# Altium schematic sheet l1.SchDoc
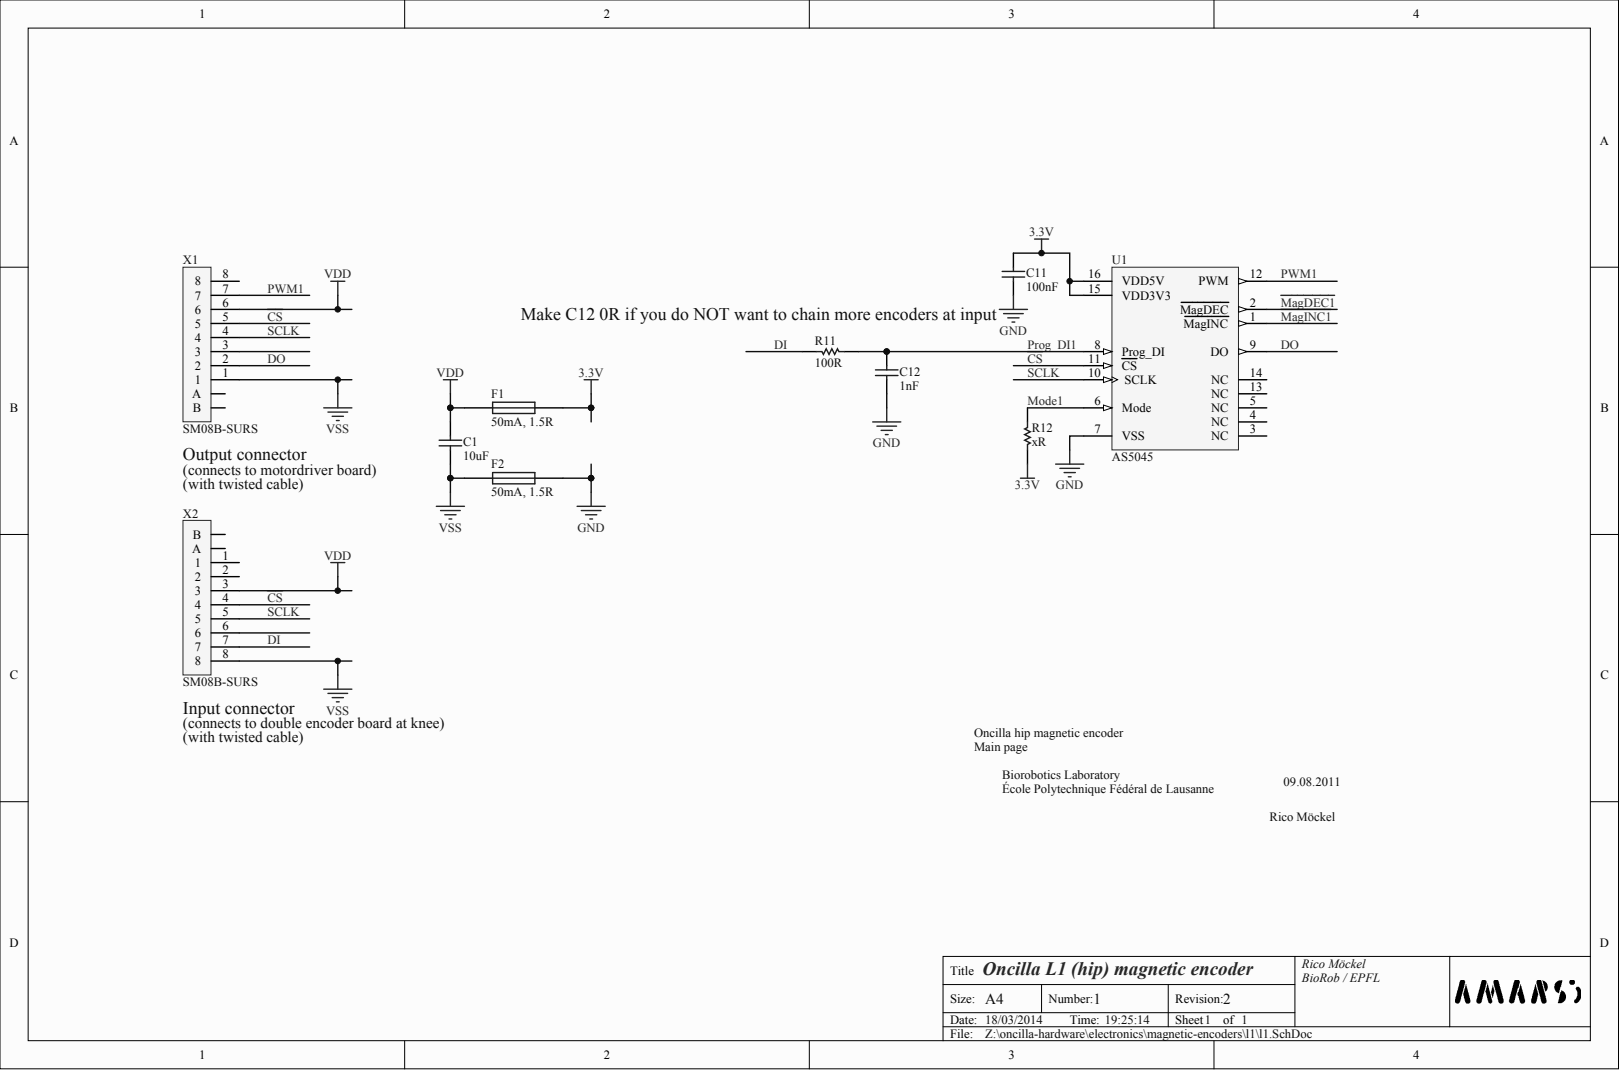
[diagram p0: l1.SchDoc]

## Parts
C1  10uF
C11  100nF
C12  1nF
F1  50mA, 1.5R
F2  50mA, 1.5R
R11  100R
R12  xR
U1  AS5045
X1  SM08B-SURS
X2  SM08B-SURS

## Nets
3.3V: C11.1 F1.2 R12.1 U1.15(VDD3V3) U1.16(VDD5V)
GND: C11.2 C12.2 F2.2 U1.7(VSS)
VDD: C1.2 F1.1 X1.6 X2.3
VSS: C1.1 F2.1 X1.1 X2.8
~{CS}: U1.11(CS) X1.5 X2.4
DI: R11.1 X2.7
DO: U1.9(DO) X1.2
~{MAGDEC1}: U1.2(MagDEC)
~{MAGINC1}: U1.1(MagINC)
MODE1: R12.2 U1.6(Mode)
PROG_DI1: C12.1 R11.2 U1.8(Prog_DI)
PWM1: U1.12(PWM) X1.7
SCLK: U1.10(SCLK) X1.4 X2.5

Not connected: U1 3(NC) 4(NC) 5(NC) 13(NC) 14(NC); X1 3 8 A B; X2 1 2 6 A B

## Library data of the parts
C1 C11 C12 F1 F2 R11 R12 U1 X1 X2 = (no symbol name)
  C1 C11 C12: Published: 8-Jun-2000; LatestRevisionDate: 17-Jul-2002; LatestRevisionNote: Re-released for DXP Platform.; PackageReference: 0603
  F1 F2: Published: 8-Jun-2000; LatestRevisionDate: 17-Jul-2002; LatestRevisionNote: Re-released for DXP Platform.; PackageReference: PIN-W2/E2.8
  R11 R12: Published: 8-Jun-2000; LatestRevisionDate: 17-Jul-2002; LatestRevisionNote: Re-released for DXP Platform.; PackageReference: AXIAL-0.3
  C1 C11 C12 F1 F2 R11 R12: Publisher: Altium Limited
